# Altium schematic sheet SchemaB-interace.SchDoc
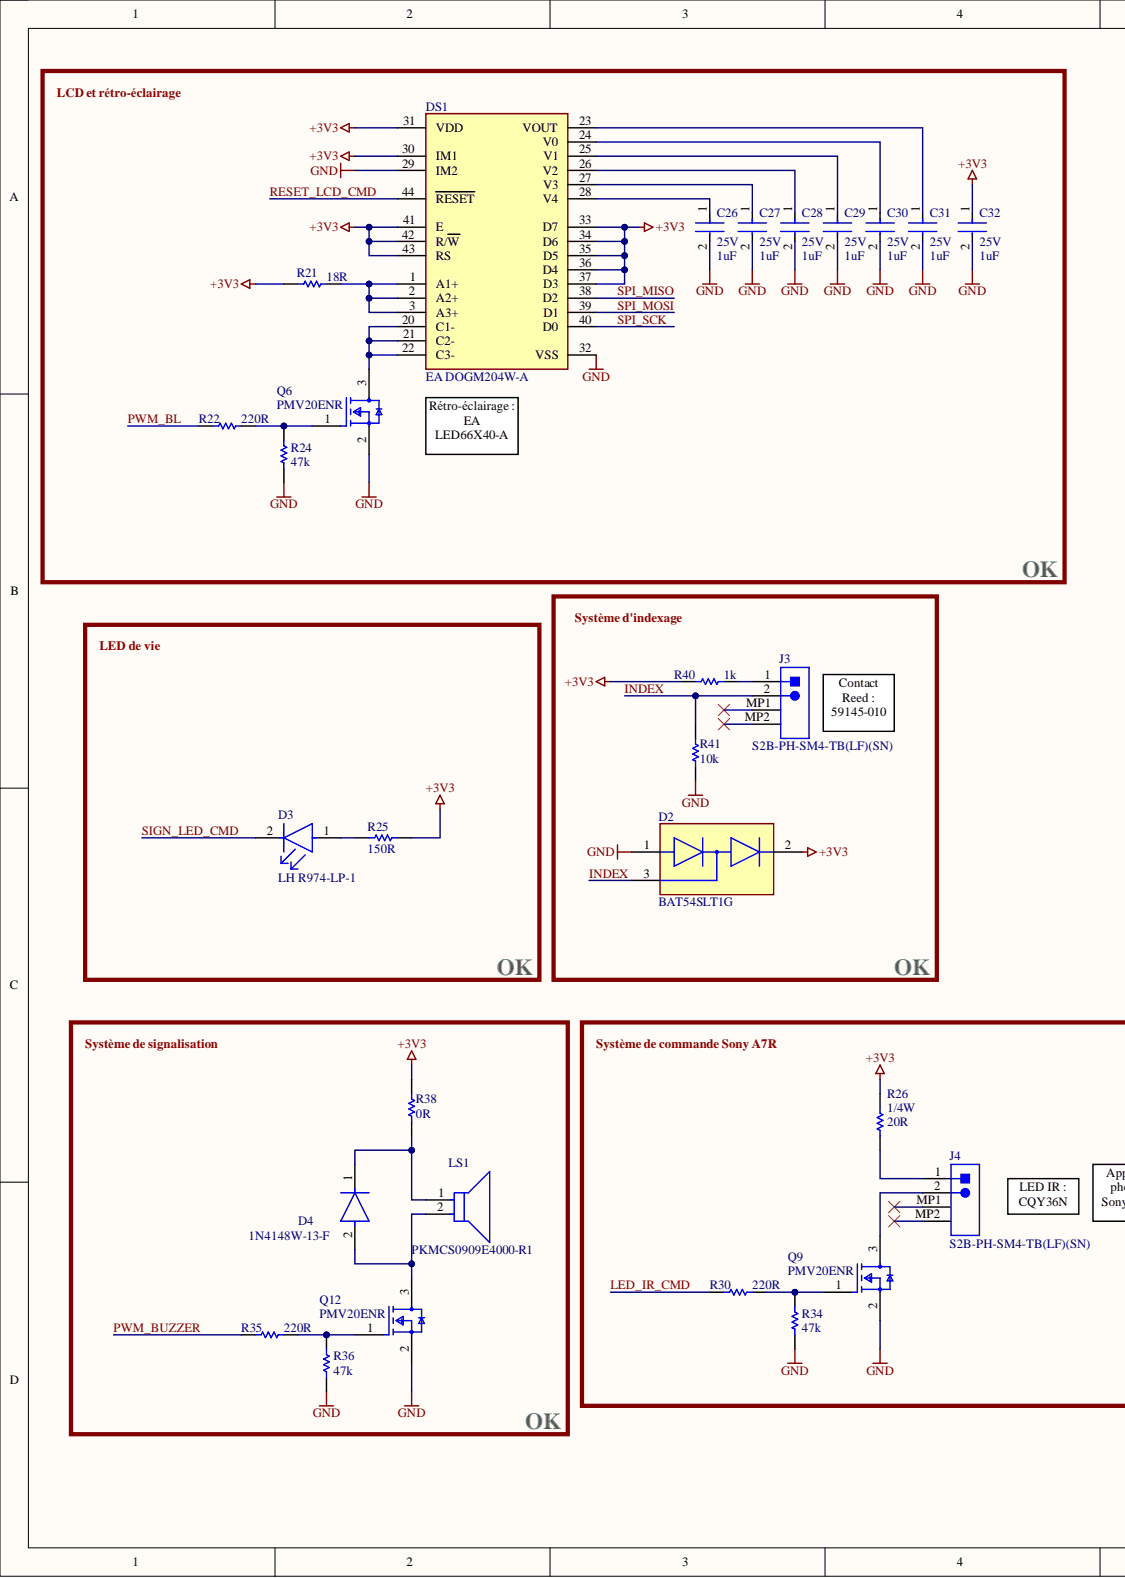
[diagram p0: SchemaB-interace.SchDoc, left]
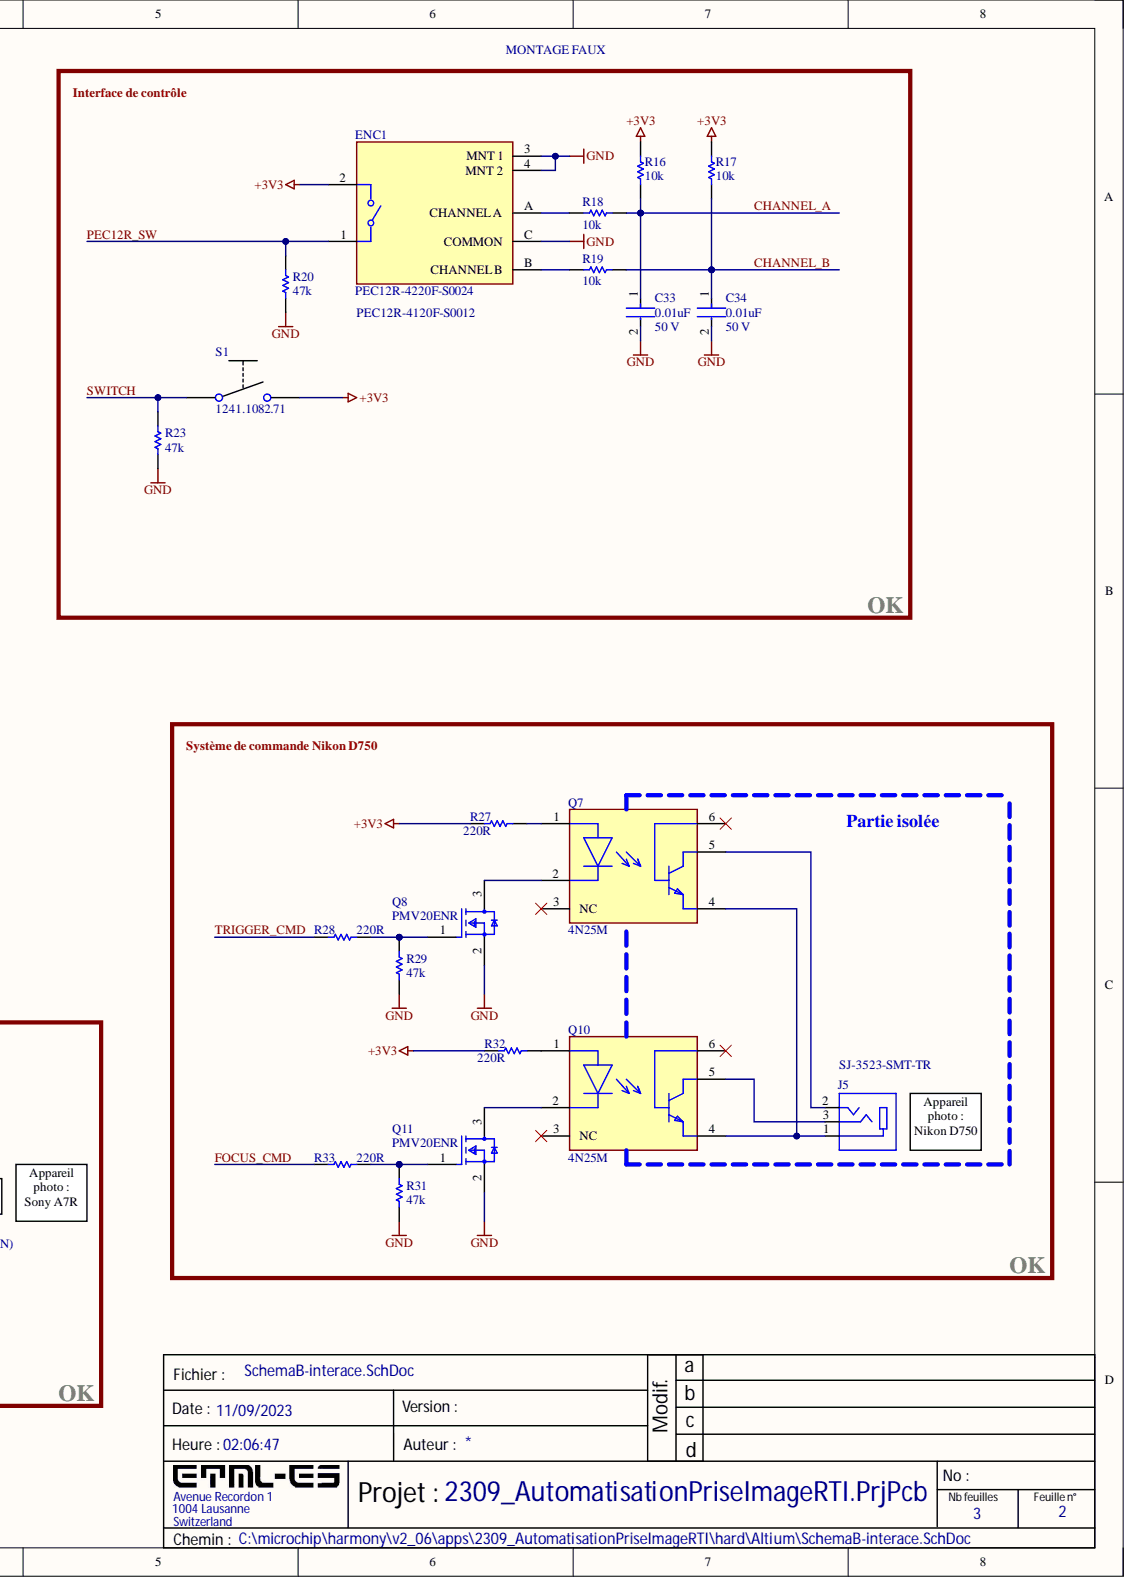
[diagram p1: SchemaB-interace.SchDoc, right]

## Parts
C26  Capacitance: 1uF  Voltage Rating: 25V  [FP-CL21-IPC_B]  (hidden comment: CL21F105ZAFNNNF)
C27  Capacitance: 1uF  Voltage Rating: 25V  [FP-CL21-IPC_B]  (hidden comment: CL21F105ZAFNNNF)
C28  Capacitance: 1uF  Voltage Rating: 25V  [FP-CL21-IPC_B]  (hidden comment: CL21F105ZAFNNNF)
C29  Capacitance: 1uF  Voltage Rating: 25V  [FP-CL21-IPC_B]  (hidden comment: CL21F105ZAFNNNF)
C30  Capacitance: 1uF  Voltage Rating: 25V  [FP-CL21-IPC_B]  (hidden comment: CL21F105ZAFNNNF)
C31  Capacitance: 1uF  Voltage Rating: 25V  [FP-CL21-IPC_B]  (hidden comment: CL21F105ZAFNNNF)
C32  Capacitance: 1uF  Voltage Rating: 25V  [FP-CL21-IPC_B]  (hidden comment: CL21F105ZAFNNNF)
C33  value 0.01uF  Voltage Rating: 50 V  [FP-C0805C-DN-MFG]  (hidden comment: C0805C103J5RAC7210)
C34  value 0.01uF  Voltage Rating: 50 V  [FP-C0805C-DN-MFG]  (hidden comment: C0805C103J5RAC7210)
D2  BAT54SLT1G  [FP-318-08-IPC_B]
D3  LH R974-LP-1  [FP-LH_R974-MFG]
D4  1N4148W-13-F  [FP-SOD123-MFG]
DS1  EA DOGM204W-A  [EA DOGM204]
ENC1  PEC12R-4220F-S0024  [FP-PEC12R-4120F-S0012-MFG]
J3  S2B-PH-SM4-TB(LF)(SN)  [JST- S2B-PH-SM4-TB(LF)(SN)-2_V]
J4  S2B-PH-SM4-TB(LF)(SN)  [JST- S2B-PH-SM4-TB(LF)(SN)-2_V]
J5  SJ-3523-SMT-TR  [CUI_SJ-3523-SMT]
LS1  PKMCS0909E4000-R1  [FP-PKMCS-MFG]
Q6  PMV20ENR  [FP-SOT23-MFG]
Q7  4N25M  [FP-646BX-MFG]
Q8  PMV20ENR  [FP-SOT23-MFG]
Q9  PMV20ENR  [FP-SOT23-MFG]
Q10  4N25M  [FP-646BX-MFG]
Q11  PMV20ENR  [FP-SOT23-MFG]
Q12  PMV20ENR  [FP-SOT23-MFG]
R16  Resistance: 10k  [RESC2013X60X35ML10T20]  (hidden comment: RC0805JR-0710KL)
R17  Resistance: 10k  [RESC2013X60X35ML10T20]  (hidden comment: RC0805JR-0710KL)
R18  Resistance: 10k  [RESC2013X60X35ML10T20]  (hidden comment: RC0805JR-0710KL)
R19  Resistance: 10k  [RESC2013X60X35ML10T20]  (hidden comment: RC0805JR-0710KL)
R20  value 47k  [RESC2013X60X35NL10T20]  (hidden comment: RC0805FR-0747KL)
R21  value 18R  [RESC2013X70X40ML20T20]  (hidden comment: ERJ6ENF18R0V)
R22  value 220R  [RESC2013X70X40NL20T20]  (hidden comment: ERJ6ENF2200V)
R23  value 47k  [RESC2013X60X35NL10T20]  (hidden comment: RC0805FR-0747KL)
R24  value 47k  [RESC2013X60X35NL10T20]  (hidden comment: RC0805FR-0747KL)
R25  value 150R  [RESC2013X60X35ML10T20]  (hidden comment: RC0805JR-0775RL)
R26  value 20R  Puissance: 1/4W  [RESC2013X60X30ML20T20]  (hidden comment: RK73B2ATTD200J)
R27  value 220R  [RESC2013X50X30NL20T20]  (hidden comment: CRCW0805210RFKEA)
R28  value 220R  [RESC2013X70X40NL20T20]  (hidden comment: ERJ6ENF2200V)
R29  value 47k  [RESC2013X60X35NL10T20]  (hidden comment: RC0805FR-0747KL)
R30  value 220R  [RESC2013X70X40NL20T20]  (hidden comment: ERJ6ENF2200V)
R31  value 47k  [RESC2013X60X35NL10T20]  (hidden comment: RC0805FR-0747KL)
R32  value 220R  [RESC2013X50X30NL20T20]  (hidden comment: CRCW0805210RFKEA)
R33  value 220R  [RESC2013X70X40NL20T20]  (hidden comment: ERJ6ENF2200V)
R34  value 47k  [RESC2013X60X35NL10T20]  (hidden comment: RC0805FR-0747KL)
R35  value 220R  [RESC2013X70X40NL20T20]  (hidden comment: ERJ6ENF2200V)
R36  value 47k  [RESC2013X60X35NL10T20]  (hidden comment: RC0805FR-0747KL)
R38  Resistance: 0R  [RESC2013X60X35ML10T20]  (hidden comment: RC0805JR-070RL)
R40  Resistance: 1k  [RESC2013X60X35ML10T20]  (hidden comment: RC0805FR-071KL)
R41  Resistance: 10k  [RESC2013X60X35ML10T20]  (hidden comment: RC0805JR-0710KL)
S1  1241.1082.71  [Tact-sw 1241.1082]

## Nets
+3V3: C32.1 D2.2 DS1.30(IM1) DS1.31(VDD) DS1.33(D7) DS1.34(D6) DS1.35(D5) DS1.36(D4) DS1.37(D3) DS1.41(E) DS1.42(R/W) DS1.43(RS) ENC1.2 R16.1 R17.1 R21.1 R25.2 R26.2 R27.1 R32.1 R38.2 R40.1 S1.2
GND: C26.2 C27.2 C28.2 C29.2 C30.2 C31.2 C32.2 C33.2 C34.2 D2.1 DS1.29(IM2) DS1.32(VSS) ENC1.3(MNT_1) ENC1.4(MNT_2) ENC1.C(COMMON) Q6.2 Q8.2 Q9.2 Q11.2 Q12.2 R20.1 R23.1 R24.1 R29.1 R31.1 R34.1 R36.1 R41.2
CHANNEL_A: C33.1 R16.2 R18.1
CHANNEL_B: C34.1 R17.2 R19.1
FOCUS_CMD: R33.1
INDEX: D2.3 J3.2 R41.1
LED_IR_CMD: R30.1
PEC12R_SW: ENC1.1 R20.2
PWM_BL: R22.1
PWM_BUZZER: R35.1
RESET_LCD_CMD: DS1.44(RESET)
SIGN_LED_CMD: D3.2
SPI_MISO: DS1.38(D2)
SPI_MOSI: DS1.39(D1)
SPI_SCK: DS1.40(D0)
SWITCH: R23.2 S1.1
TRIGGER_CMD: R28.1
NetC26_1: C26.1 DS1.28(V4)
NetC27_1: C27.1 DS1.27(V3)
NetC28_1: C28.1 DS1.26(V2)
NetC29_1: C29.1 DS1.25(V1)
NetC30_1: C30.1 DS1.24(V0)
NetC31_1: C31.1 DS1.23(VOUT)
NetD3_1: D3.1 R25.1
NetD4_1: D4.1 LS1.1 R38.1
NetD4_2: D4.2 LS1.2 Q12.3
NetDS1_1: DS1.1(A1+) DS1.2(A2+) DS1.3(A3+) R21.2
NetDS1_20: DS1.20(C1-) DS1.21(C2-) DS1.22(C3-) Q6.3
NetENC1_A: ENC1.A(CHANNEL_A) R18.2
NetENC1_B: ENC1.B(CHANNEL_B) R19.2
NetJ3_1: J3.1 R40.2
NetJ4_1: J4.1 R26.1
NetJ4_2: J4.2 Q9.3
NetJ5_1: J5.1 Q7.4 Q10.4
NetJ5_2: J5.2 Q7.5
NetJ5_3: J5.3 Q10.5
NetQ6_1: Q6.1 R22.2 R24.2
NetQ7_1: Q7.1 R27.2
NetQ7_2: Q7.2 Q8.3
NetQ8_1: Q8.1 R28.2 R29.2
NetQ9_1: Q9.1 R30.2 R34.2
NetQ10_1: Q10.1 R32.2
NetQ10_2: Q10.2 Q11.3
NetQ11_1: Q11.1 R31.2 R33.2
NetQ12_1: Q12.1 R35.2 R36.2

Not connected: J3 MP1 MP2; J4 MP1 MP2; Q7 3(NC) 6; Q10 3(NC) 6

## Library data of the parts
C26-C32 = CMP-13271-002018-1: CL21 Series 0805 1uF 25V -20/+80% Tolerance Y5V Multilayer Ceramic Chip Capacitor; Tolerance: 80%; Case/Package: 0805; Component Kind: Standard; Depth: 1.25mm; Dielectric: Y5V; Height: 1.25mm; Length: 2mm; Library Name: Altium Content Vault; Max Operating Temperature: 85°C; Min Operating Temperature: -30°C; Mount: Surface Mount; Package Quantity: 10000; Packaging: Tape and Reel; Radiation Hardening: No; Thickness: 0.053inch; Voltage Rating (DC): 25V; Width: 0.049inch
C33 C34 = CMP-2007-01173-3: CAP CER SMD 0805 .01UF X7R 5% 50; Manufacturer: KEMET; Capacitance: 10,000 pF; Material: Ceramic; Temperature Coefficient: 30ppm/°C; Tolerance: 0.05; Automotive: No; Category: Cap; Component Kind: Standard; ComponentLink2Description: Datasheet; ComponentLink2URL: https://content.kemet.com/datasheets/KEM_C1003_C0G_SMD.pdf; Device Class L1: Passive Components; Device Class L2: Capacitors; Device Class L3: Ceramic Capacitors; Digikey Description: CAP CER SMD 0805 .01UF X7R 5% 50; Height: 0.88mm; Ipc Land Pattern Name: CAPC200125X78; Lead Free: Yes; Library Name: Altium Content Vault; Manufacturer Part Number: C0805C103J5RAC7210; Mouser Description: Cap Ceramic 0.01uF 50V X7R 5% Pad SMD 0805 125C T/R; Octopart: https://octopart.com/c0805c103j5rac7210-kemet-3921106; Package: C0805C-DN; RoHS: TRUE; Temperature: -55°C to +125°C; Temperature Characteristic: X7R; Temperature Range High: +125°C; Temperature Range Low: -55°C
D2 = CMP-1055-00536-2: DIODE ARRAY SCHOTTKY 30V SOT23-3; Average Rectified Current: 200mA; Capacitance: 10pF; Element Configuration: Dual; Forward Voltage: 800mV; Max Forward Surge Current (Ifsm): 600mA; Max Reverse Leakage Current: 2uA; Peak Non-Repetitive Surge Current: 1A; Power Dissipation: 225mW; Recovery Time: 5ns; Case/Package: SOT-23-3; Component Kind: Standard; Contact Plating: Tin; Current Rating: 200mA; Forward Current: 200mA; Halogen Free: Halogen Free; Height: 0.94mm; Lead Free: Lead Free; Length: 2.9mm; Library Name: Altium Content Vault; Max Operating Temperature: 150°C; Max Repetitive Reverse Voltage (Vrrm): 30V; Max Reverse Voltage (DC): 30V; Max Surge Current: 600mA; Min Operating Temperature: -55°C; Number of Pins: 3; Package Quantity: 3000; Packaging: Tape and Reel; Peak Reverse Current: 2uA; Radiation Hardening: No; REACH SVHC: No SVHC; Reverse Recovery Time: 5ns; Voltage Rating (DC): 30V; Width: 1.3mm
D3 = CMP-2000-06540-2: LED RED DIFFUSED 0805 SMD; Dominant Wavelength: 645nm; Forward Current: 30mA; Forward Voltage: 2.6V; Luminous Intensity: 15mcd; Number of Elements: 1; Reverse Voltage: 5V; Test Current: 20mA; Viewing Angle: 160°; Component Kind: Standard; Depth: 1.35mm; Length: 2.1mm; Lens Style: Diffused; Library Name: Altium Content Vault; Max Operating Temperature: 85°C; Min Operating Temperature: -30°C; Mount: Surface Mount; Number of Pins: 2; Packaging: Tape and Reel; Radiation Hardening: No
D4 = CMP-12791-000005-1: DIODE GEN PURP 100V 150MA SOD123; Manufacturer: Diodes Inc.; Capacitance: 2pF; Forward Current: 300mA; Max Junction Temp: +150°C; Max Surge Forward Current: 1A; Peak Reverse Current: 1uA; Standoff Height: 0mm; Value: 2pF; Automotive: No; Category: Diode; Component Kind: Standard; ComponentLink2Description: Datasheet; ComponentLink2URL: https://upverter.com/datasheet/628e7d6fee5901eee6eef4567d1c3569f2cd3cde.pdf; Device Class L1: Discrete Semiconductors; Device Class L2: Diodes; Device Class L3: Rectifier Diodes; Digikey Description: DIODE GEN PURP 100V 150MA SOD123; Footprint Url: https://www.diodes.com/assets/Package-Files/SOD123.pdf; Height: 1.45mm; Lead Free: Yes; Library Name: Altium Content Vault; Manufacturer Part Number: 1N4148W-13-F; Max Repetitive Reverse Voltage: 100V; Mouser Description: 1N4148W Series 2 A 100 V 400 mW Surface Mount Fast Switching Diode - SOD-123; Octopart: https://octopart.com/1n4148w-13-f-diodes+inc.-2359612; Package: SOD123; Reverse Voltage: 100V; RoHS: TRUE; Temperature: -65°C to +150°C; Temperature Range High: +150°C; Temperature Range Low: -65°C
DS1 = EA DOGM204: Component Kind: Standard; Library Name: SymbLib.SchLib
ENC1 = PEC12R-4220F-S0024: ROTARY ENCODER MECHANICAL 24PPR; Built in Switch: Yes; Hole Diameter: 2mm; Max Supply Voltage (DC): 5V; Number of Channels: 2; Output Type: Quadrature; Pulses per Revolution: 24; Component Kind: Standard; Detent: Yes; Encoder Type: Mechanical; Height: 13.4mm; Length: 26mm; Max Operating Temperature: 70°C; Min Operating Temperature: -30°C; Mount: Through Hole; Orientation: Vertical; Package Quantity: 150; Packaging: Bulk; REACH SVHC: No SVHC; RoHS Compliant: Yes; Series: PEC12R; Termination: PC Pin; Width: 12.5mm; Library Name: SymbLib.SchLib
J3 J4 = CMP-1755-00001-1: Male Header, Pitch 2 mm, 1 x 2 Position, Height 5.5 mm, -25 to 85 degC, RoHS, Tape and Reel; Current Rating: 3 A; Colour: Black; Component Kind: Standard; ComponentLink1Description: Manufacturer URL; ComponentLink1URL: http://www.jst.com/home2.html; ComponentLink2Description: Datasheet; ComponentLink2URL: http://www.jst-mfg.com/product/pdf/eng/ePH.pdf; Contact Finish: Lead; Contact Material: Bronze; Contact Plating: Tin; DatasheetVersion: Dec-12; Height: 8.13 mm; Lead Pitch: 0.1 inch; Length: 101.19 mm; Library Name: Altium Content Vault; Max Operating Temperature: 105degC; Min Operating Temperature: -65degC; Mount: Through Hole; Package Quantity: 100; PackageDescription: Male Header, Pitch 2 mm, 1 x 2 Position, Height 5.5 mm; PackageReference: S2B-PH-SM4-TB(LF)(SN)-2; Packaging: Bulk; Pins: 40; Radiation Hardening: No; RoHSCompliant: True; Width: 2.34 mm
J5 = SJ-3523-SMT: Component Kind: Standard; Library Name: SymbLib.SchLib
LS1 = CMP-2000-05252-2: AUDIO PIEZO TRANSDUCER 12.5V SMD; Frequency: 4kHz; Min Supply Voltage (DC): -12.5V; Voltage Rating: 1.5V; Component Kind: Standard; Depth: 9mm; Height: 1.9mm; Lead Free: Lead Free; Length: 9mm; Library Name: Altium Content Vault; Max Operating Temperature: 85°C; Max Supply Voltage (DC): 12.5V; Min Operating Temperature: -40°C; Mount: Surface Mount; Package Quantity: 2000; Packaging: Tape and Reel; Radiation Hardening: No; REACH SVHC: No SVHC; Self Resonant Frequency: 4kHz; Termination: Solder; Voltage Rating (DC): 12.5V; Width: 9mm
Q6 Q8 Q9 Q11 Q12 = CMP-14409-000013-1: MOSFET N-CH 30V SOT23; Component Kind: Standard; Library Name: Altium Content Vault
Q7 Q10 = CMP-00278-84323282-1: 4N25M Optocoupler DC-IN 1-CH Transistor With Base DC-OUT 6-Pin PDIP Tube - Bulk; Manufacturer Lifecycle Status: ACTIVE; Approvals: UL; Collector Emitter Breakdown Voltage: 30V; Collector Emitter Saturation Voltage: 500mV; Current Transfer Ratio: 20%; Fall Time: 2µs; Forward Current: 10mA; Forward Voltage: 1.18V; Isolation Voltage: 7.5kV; Max Input Current: 50mA; Max Junction Temperature (Tj): 125°C; Max Power Dissipation: 200mW; Number of Channels: 1; Power Dissipation: 250mW; Reverse Breakdown Voltage: 6V; Case/Package: DIP; Collector Emitter Voltage (VCEO): 500mV; Component Kind: Standard; Height: 5.08mm; Input Current: 10mA; Lead Free: Lead Free; Length: 7.12mm; Library Name: Altium Content Vault; Lifecycle Status: Production; Max Operating Temperature: 100°C; Max Output Voltage: 30V; Min Operating Temperature: -55°C; Mount: Through Hole; NexarPartId: 84323282; Number of Circuits: 1; Number of Elements: 1; Number of Pins: 6; Output Voltage: 30V; Radiation Hardening: No; REACH SVHC: No SVHC; Reverse Voltage: 6V; Rise Time: 2µs; RoHS: Compliant; Schedule B: 8541408000, 8541408000; Turn-Off Delay Time: 2µs; Turn-On Delay Time: 2µs; Weight: 855mg; Width: 6.5mm
R16-R19 R41 = CMP-2000-05025-1: Chip Resistor, 10 KOhm, +/- 5%, 0.125 W, -55 to 155 degC, 0805 (2012 Metric), RoHS, Tape and Reel; Manufacturer: Yageo; Power Rating: 250mW; Product Range: RCSeries; Resistor Case Style: 0805[2012Metric]; Resistor Element Type: ThickFilm; SVHC: ToBeAdvised; Temperature Coefficient: ±100ppm/degC; Voltage Rating: 150V; Automotive Qualification Standard: -; Component Kind: Standard; Datasheet URL: http://www.yageo.ru/pdf/RC0805.pdf; Datasheet Version: REV. 2, 09/2004; Library Name: Altium Content Vault; Manufacturer URL: http://www.yageo.com/; MSL: MSL1-Unlimited; Package Description: 2-Pin Surface Mounted Device, Body 2 x 1.25 mm; Package Reference: 805; Packaging: CutTape; Resistance Tolerance: ±5%
R20 R23 R24 R29 R31 R34 R36 = CMP-1659-00011-4: Chip Resistor, 47 KOhm, +/- 1%, 0.125 W, -55 to 155 degC, 0805 (2012 Metric), RoHS, Tape and Reel; Manufacturer: Yageo; Voltage Rating: 150 V; Component Kind: Standard; ComponentLink1Description: Manufacturer URL; ComponentLink1URL: http://www.yageo.com/; ComponentLink2Description: Datasheet; ComponentLink2URL: http://www.yageo.com/documents/recent/PYu-RC_Group_51_RoHS_L_04.pdf; DatasheetVersion: Rev. 4, 02/2016; Height: 0.5 mm; Length: 2 mm; Library Name: Altium Content Vault; Max Operating Temperature: 155 degC; Min Operating Temperature: -55 degC; Mount: Surface Mount; Mounting Technology: Surface Mount; Package Quantity: 200; PackageDescription: 2-Pin Surface Mounted Device, Body 2 x 1.25 mm; PackageReference: 0805; Packaging: Tape and Reel; Pins: 2; REACH SVHC: No SVHC; RoHSCompliant: true
R21 = CMP-2001-00520-1: Manufacturer: Panasonic; Component Kind: Standard; Library Name: Altium Content Vault; Part Number: ERJ6ENF18R0V
R22 R28 R30 R33 R35 = CMP-2001-00044-1: Manufacturer: Panasonic; Component Kind: Standard; Library Name: Altium Content Vault; Part Number: ERJ6ENF2200V
R25 = CMP-2001-01402-1: Manufacturer: Yageo; Component Kind: Standard; Library Name: Altium Content Vault; Part Number: RC0805JR-0775RL
R26 = CMP-2001-01797-1: Manufacturer: KOA Speer; Component Kind: Standard; Library Name: Altium Content Vault; Part Number: RK73B2ATTD200J
R27 R32 = CMP-1013-00431-2: Manufacturer: Vishay Dale; Component Kind: Standard; Library Name: Altium Content Vault; Part Number: CRCW0805210RFKEA
R38 = CMP-2100-03673-1: Chip Resistor, 0 Ohm, Jumper, +/- 5%, 0.125 W, -55 to 155 degC, 0805 (2012 Metric), RoHS, Tape and Reel; Manufacturer: Yageo; Power Rating: 125mW; Temperature Coefficient: 200ppm/degreesC; Voltage Rating: 150V; Case Code (Imperial): 0805; Case Code (Metric): 2012; Case/Package: 0805; Component Kind: Standard; Composition: ThickFilm; Contact Plating: Tin; Datasheet URL: http://www.yageo.com/NewPortal/yageodocoutput?fileName=/pdf/R-Chip/PYu-RC_Group_51_RoHS_L_5.pdf; Datasheet Version: Rev. 5, 10/2016; Depth: 1.25mm; Height: 0.5mm; Lead Free: LeadFree; Length: 2mm; Library Name: Altium Content Vault; Manufacturer URL: http://www.yageo.com/; Max Operating Temperature: 155degC; Military Standard: Not; Min Operating Temperature: -55degC; Number of Pins: 2; Package Description: 2-Pin Surface Mounted Device, Body 2 x 1.25 mm; Package Reference: 0805; Packaging: TapeandReel; Radiation Hardening: No; REACH SVHC: Unknown; RoHSCompliant: true; Termination: SMD/SMT; Tolerance: 5%; Voltage Rating (DC): 150V; Width: 1.25mm
R40 = CMP-2100-03671-1: Chip Resistor, 1 KOhm, +/- 1%, 125 mW, -55 to 155 degC, 0805 (2012 Metric), RoHS, Tape and Reel; Manufacturer: Yageo; Max Power Dissipation: 125mW; Temperature Coefficient: 100ppm/degreesC; Voltage Rating: 150V; Case Code (Imperial): 0805; Case Code (Metric): 2012; Case/Package: 0805; Component Kind: Standard; Composition: ThickFilm; Datasheet URL: http://www.yageo.com/NewPortal/yageodocoutput?fileName=/pdf/R-Chip/PYu-RC_Group_51_RoHS_L_5.pdf; Datasheet Version: Rev. 5, 10/2016; Depth: 1.25mm; Height: 0.024inch; Lead Free: LeadFree; Length: 0.079inch; Library Name: Altium Content Vault; Manufacturer URL: http://www.yageo.com/; Max Operating Temperature: 155degC; Military Standard: Not; Min Operating Temperature: -55degC; Number of Pins: 2; Number of Terminations: 2; Package Description: 2-Pin Surface Mounted Device, Body 2 x 1.25 mm; Package Quantity: 1; Package Reference: 0805; Packaging: TapeandReel; Power Rating: 125mW; REACH SVHC: Unknown; RoHSCompliant: true; Termination: SMD/SMT; Tolerance: 1%; Voltage Rating (DC): 150V; Width: 0.049inch
S1 = Tact-sw 1241.1082: Component Kind: Standard; Library Name: SymbLib.SchLib
